# Altium schematic sheet matrix_right.SchDoc
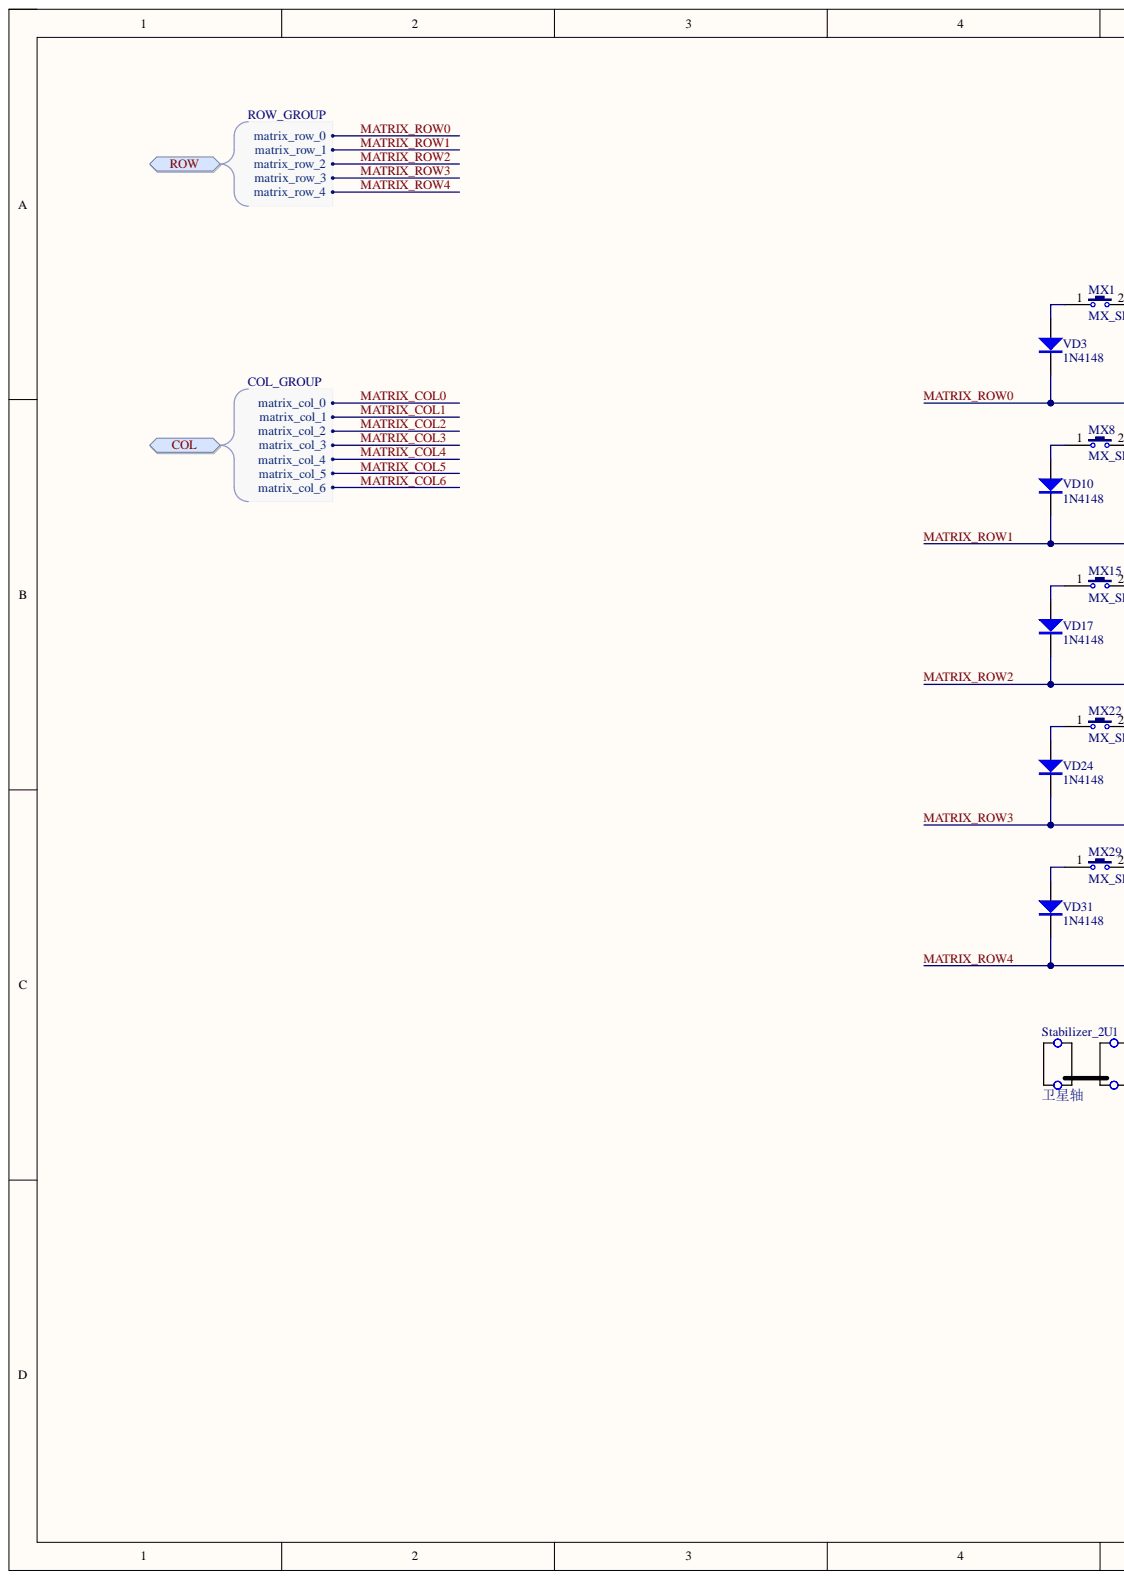
[diagram p0: matrix_right.SchDoc, left]
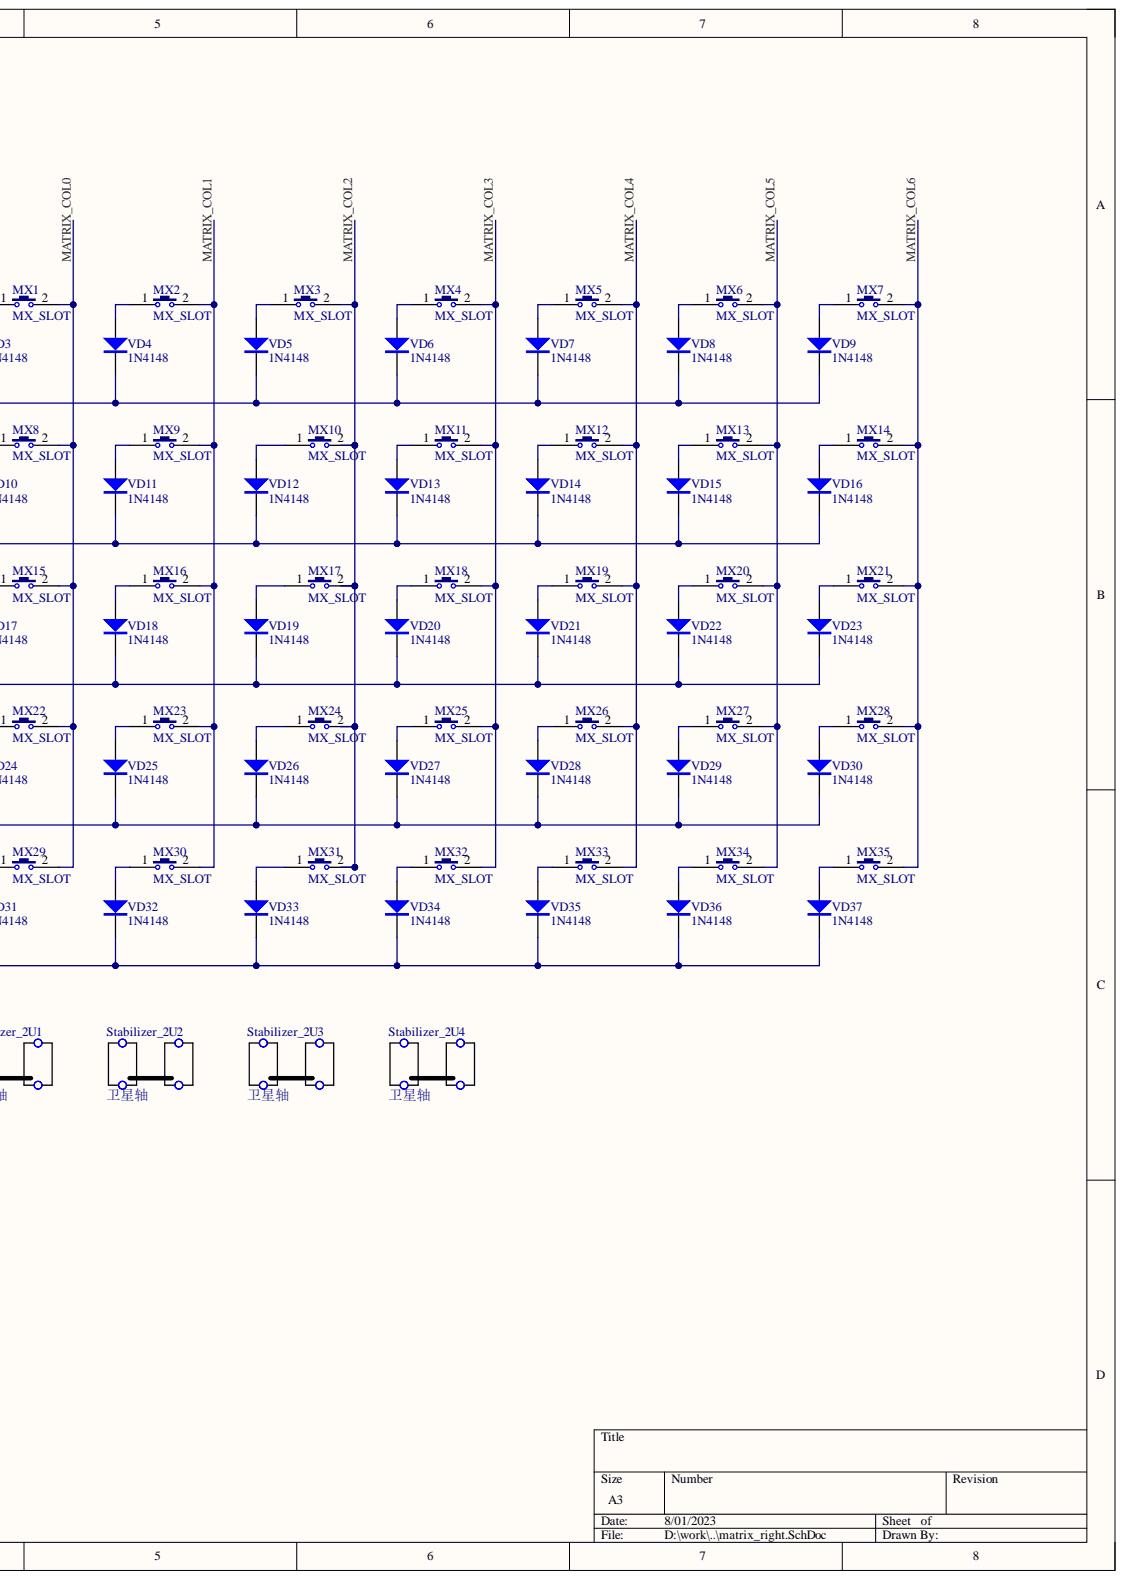
[diagram p1: matrix_right.SchDoc, right]

## Parts
MX1  MX_SLOT  [GATERON_MX_SLOT]
MX2  MX_SLOT  [GATERON_MX_SLOT]
MX3  MX_SLOT  [GATERON_MX_SLOT]
MX4  MX_SLOT  [GATERON_MX_SLOT]
MX5  MX_SLOT  [GATERON_MX_SLOT]
MX6  MX_SLOT  [GATERON_MX_SLOT]
MX7  MX_SLOT  [GATERON_MX_SLOT]
MX8  MX_SLOT  [GATERON_MX_SLOT]
MX9  MX_SLOT  [GATERON_MX_SLOT]
MX10  MX_SLOT  [GATERON_MX_SLOT]
MX11  MX_SLOT  [GATERON_MX_SLOT]
MX12  MX_SLOT  [GATERON_MX_SLOT]
MX13  MX_SLOT  [GATERON_MX_SLOT]
MX14  MX_SLOT  [GATERON_MX_SLOT]
MX15  MX_SLOT  [GATERON_MX_SLOT]
MX16  MX_SLOT  [GATERON_MX_SLOT]
MX17  MX_SLOT  [GATERON_MX_SLOT]
MX18  MX_SLOT  [GATERON_MX_SLOT]
MX19  MX_SLOT  [GATERON_MX_SLOT]
MX20  MX_SLOT  [GATERON_MX_SLOT]
MX21  MX_SLOT  [GATERON_MX_SLOT]
MX22  MX_SLOT  [GATERON_MX_SLOT]
MX23  MX_SLOT  [GATERON_MX_SLOT]
MX24  MX_SLOT  [GATERON_MX_SLOT]
MX25  MX_SLOT  [GATERON_MX_SLOT]
MX26  MX_SLOT  [GATERON_MX_SLOT]
MX27  MX_SLOT  [GATERON_MX_SLOT]
MX28  MX_SLOT  [GATERON_MX_SLOT]
MX29  MX_SLOT  [GATERON_MX_SLOT]
MX30  MX_SLOT  [GATERON_MX_SLOT]
MX31  MX_SLOT  [GATERON_MX_SLOT]
MX32  MX_SLOT  [GATERON_MX_SLOT]
MX33  MX_SLOT  [GATERON_MX_SLOT]
MX34  MX_SLOT  [GATERON_MX_SLOT]
MX35  MX_SLOT  [GATERON_MX_SLOT]
Stabilizer_2U1  卫星轴  [Stabilizer_2U]
Stabilizer_2U2  卫星轴  [Stabilizer_2U]
Stabilizer_2U3  卫星轴  [Stabilizer_2U]
Stabilizer_2U4  卫星轴  [Stabilizer_2U]
VD3  1N4148  [SOD-323]
VD4  1N4148  [SOD-323]
VD5  1N4148  [SOD-323]
VD6  1N4148  [SOD-323]
VD7  1N4148  [SOD-323]
VD8  1N4148  [SOD-323]
VD9  1N4148  [SOD-323]
VD10  1N4148  [SOD-323]
VD11  1N4148  [SOD-323]
VD12  1N4148  [SOD-323]
VD13  1N4148  [SOD-323]
VD14  1N4148  [SOD-323]
VD15  1N4148  [SOD-323]
VD16  1N4148  [SOD-323]
VD17  1N4148  [SOD-323]
VD18  1N4148  [SOD-323]
VD19  1N4148  [SOD-323]
VD20  1N4148  [SOD-323]
VD21  1N4148  [SOD-323]
VD22  1N4148  [SOD-323]
VD23  1N4148  [SOD-323]
VD24  1N4148  [SOD-323]
VD25  1N4148  [SOD-323]
VD26  1N4148  [SOD-323]
VD27  1N4148  [SOD-323]
VD28  1N4148  [SOD-323]
VD29  1N4148  [SOD-323]
VD30  1N4148  [SOD-323]
VD31  1N4148  [SOD-323]
VD32  1N4148  [SOD-323]
VD33  1N4148  [SOD-323]
VD34  1N4148  [SOD-323]
VD35  1N4148  [SOD-323]
VD36  1N4148  [SOD-323]
VD37  1N4148  [SOD-323]

## Ports
COL, COL.matrix_col_0, COL.matrix_col_1, COL.matrix_col_2, COL.matrix_col_3, COL.matrix_col_4, COL.matrix_col_5, COL.matrix_col_6, ROW, ROW.matrix_row_0, ROW.matrix_row_1, ROW.matrix_row_2, ROW.matrix_row_3, ROW.matrix_row_4

## Nets
MATRIX_COL0: MX1.2 MX8.2 MX15.2 MX22.2 MX29.2 ; port COL
MATRIX_COL1: MX2.2 MX9.2 MX16.2 MX23.2 MX30.2 ; port COL
MATRIX_COL2: MX3.2 MX10.2 MX17.2 MX24.2 MX31.2 ; port COL
MATRIX_COL3: MX4.2 MX11.2 MX18.2 MX25.2 MX32.2 ; port COL
MATRIX_COL4: MX5.2 MX12.2 MX19.2 MX26.2 MX33.2 ; port COL
MATRIX_COL5: MX6.2 MX13.2 MX20.2 MX27.2 MX34.2 ; port COL
MATRIX_COL6: MX7.2 MX14.2 MX21.2 MX28.2 MX35.2 ; port COL
MATRIX_ROW0: VD3.2 VD4.2 VD5.2 VD6.2 VD7.2 VD8.2 VD9.2 ; port ROW
MATRIX_ROW1: VD10.2 VD11.2 VD12.2 VD13.2 VD14.2 VD15.2 VD16.2 ; port ROW
MATRIX_ROW2: VD17.2 VD18.2 VD19.2 VD20.2 VD21.2 VD22.2 VD23.2 ; port ROW
MATRIX_ROW3: VD24.2 VD25.2 VD26.2 VD27.2 VD28.2 VD29.2 VD30.2 ; port ROW
MATRIX_ROW4: VD31.2 VD32.2 VD33.2 VD34.2 VD35.2 VD36.2 VD37.2 ; port ROW
NetMX1_1: MX1.1 VD3.1
NetMX2_1: MX2.1 VD4.1
NetMX3_1: MX3.1 VD5.1
NetMX4_1: MX4.1 VD6.1
NetMX5_1: MX5.1 VD7.1
NetMX6_1: MX6.1 VD8.1
NetMX7_1: MX7.1 VD9.1
NetMX8_1: MX8.1 VD10.1
NetMX9_1: MX9.1 VD11.1
NetMX10_1: MX10.1 VD12.1
NetMX11_1: MX11.1 VD13.1
NetMX12_1: MX12.1 VD14.1
NetMX13_1: MX13.1 VD15.1
NetMX14_1: MX14.1 VD16.1
NetMX15_1: MX15.1 VD17.1
NetMX16_1: MX16.1 VD18.1
NetMX17_1: MX17.1 VD19.1
NetMX18_1: MX18.1 VD20.1
NetMX19_1: MX19.1 VD21.1
NetMX20_1: MX20.1 VD22.1
NetMX21_1: MX21.1 VD23.1
NetMX22_1: MX22.1 VD24.1
NetMX23_1: MX23.1 VD25.1
NetMX24_1: MX24.1 VD26.1
NetMX25_1: MX25.1 VD27.1
NetMX26_1: MX26.1 VD28.1
NetMX27_1: MX27.1 VD29.1
NetMX28_1: MX28.1 VD30.1
NetMX29_1: MX29.1 VD31.1
NetMX30_1: MX30.1 VD32.1
NetMX31_1: MX31.1 VD33.1
NetMX32_1: MX32.1 VD34.1
NetMX33_1: MX33.1 VD35.1
NetMX34_1: MX34.1 VD36.1
NetMX35_1: MX35.1 VD37.1

## Library data of the parts
MX1-MX35 = GATERON_MX_SLOT: GATERON佳达隆热插拔底座轴体开关改装配件; Component Kind: Standard; Library Name: Connector.SchLib
Stabilizer_2U1-Stabilizer_2U4 = Stabilizer_2U: 樱桃MX轴-2U卫星轴; Component Kind: Standard; Library Name: Connector.SchLib
VD3-VD37 = 1N4148: 高速开关二极管; 版权归属: 源创客; BOM名称: 高速开关二极管; Component Kind: Standard; Library Name: 二极管-整流桥.SchLib; 学习资源: www.ooocke.com
